# Altium schematic sheet Estimador.SchDoc
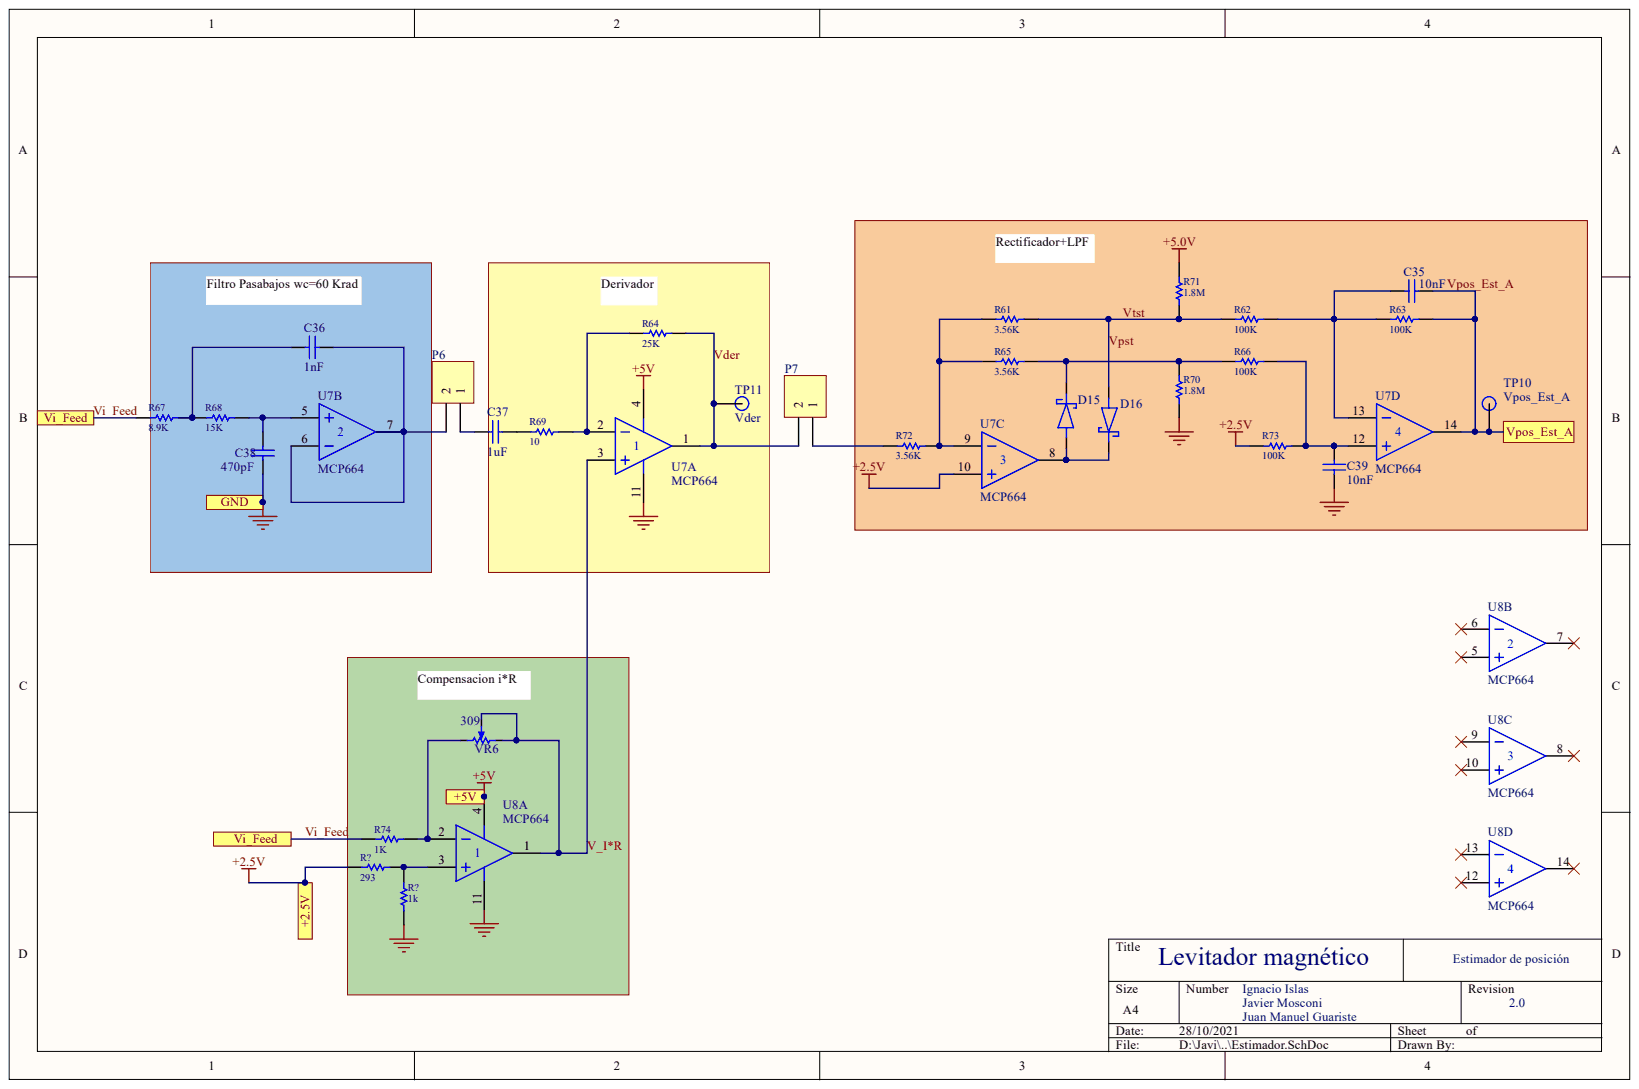
[diagram p0: Estimador.SchDoc]

## Parts
C35  value 10nF  [C1206]  (hidden comment: Capacitor)
C36  value 1nF  [C1206]  (hidden comment: Capacitor)
C37  value 1uF  [C1206]  (hidden comment: Capacitor)
C38  value 470pF  [C1206]  (hidden comment: Capacitor)
C39  value 10nF  [C1206]  (hidden comment: Capacitor)
D15  RSX205LAM30TR  [SODFL4725X105N]  (value not drawn)
D16  RSX205LAM30TR  [SODFL4725X105N]  (value not drawn)
P6  Header 2  [HDR1X2]  (value not drawn)
P7  Header 2  [HDR1X2]  (value not drawn)
R61  3.56K  [R1206]
R62  100K  [R1206]
R63  100K  [R1206]
R64  25K  [R1206]
R65  3.56K  [R1206]
R66  100K  [R1206]
R67  8.9K  [R1206]
R68  15K  [R1206]
R69  10  [R1206]
R70  1.8M  [R1206]
R71  1.8M  [R1206]
R72  3.56K  [R1206]
R73  100K  [R1206]
R74  1K  [R1206]
R?  1k  [R1206]
R?  293  [R1206]
TP10  Vpos_Est_A  [TestPoint Keystone 5000 Silver-Red]
TP11  Vder  [TestPoint Keystone 5000 Silver-Red]
U7  MCP664  [SOIC14]  (parts A,B,C,D)
U8  MCP664  [SOIC14]  (parts A,B,C,D)
VR6  value 309  [3299Y1203LF]  (hidden comment: Trimmer (Thru-Hole))

## Ports
+2.5V, +5V, GND, VI_FEED, VPOS_EST_A

## Nets
+5.0V: R71.2
+2.5V: R73.2 R?.1 U7.10 ; port +2.5V
+5V: U7.4 U8.4 ; port +5V
GND: C38.2 C39.1 R70.1 R?.1 U7.11 U8.11 ; port GND
V_I*R: U7.3 U8.1 VR6.2 VR6.3
VDER: P7.2 R64.2 TP11.1 U7.1
VI_FEED: R67.1 R74.1 ; port VI_FEED
VPOS_EST_A: C35.1 R63.1 TP10.1 U7.14 ; port VPOS_EST_A
VPST: D16.2 R61.2 R62.1 R71.1
NetC35_2: C35.2 R62.2 R63.2 U7.13
NetC36_1: C36.1 P6.2 U7.6 U7.7
NetC36_2: C36.2 R67.2 R68.1
NetC37_1: C37.1 P6.1
NetC37_2: C37.2 R69.1
NetC38_1: C38.1 R68.2 U7.5
NetC39_2: C39.2 R66.2 R73.1 U7.12
NetD15_1: D15.1 R65.2 R66.1 R70.2
NetD15_2: D15.2 D16.1 U7.8
NetP7_1: P7.1 R72.1
NetR61_1: R61.1 R65.1 R72.2 U7.9
NetR64_1: R64.1 R69.2 U7.2
NetR74_2: R74.2 U8.2 VR6.1
NetR?_2: R?.2 U8.3

Not connected: U8 5 6 7 8 9 10 12 13 14

## Library data of the parts
C35-C39 = Capacitor: Manufacturer: Generic Components; Class: Passive; Component Kind: Standard; Description: Capacitor; Published: 08/06/2000; SubClass: Capacitor; Library Name: Levitador_GMI.SchLib; Publisher: Altium Hobart Technology Centre
D15 D16 = RSX205LAM30TR: Schottky Diode; Manufacturer_Name: ROHM Semiconductor; Component Kind: Standard; Datasheet Link: https://fscdn.rohm.com/en/products/databook/datasheet/discrete/diode/schottky_barrier/rsx205lam30tr-e.pdf; Height: 1.05mm; Manufacturer_Part_Number: RSX205LAM30TR; Mouser Part Number: 755-RSX205LAM30TR; Mouser Price/Stock: https://www.mouser.co.uk/ProductDetail/ROHM-Semiconductor/RSX205LAM30TR?qs=gt1LBUVyoHmFfSK22qN0cQ%3D%3D; Library Name: Levitador_GMI.SchLib
P6 P7 = Header 2: Header, 2-Pin; Component Kind: Standard; LatestRevisionDate: 17-Jul-2002; LatestRevisionNote: Re-released for DXP Platform.; Link: https://www.digikey.com/en/products/detail/te-connectivity-amp-connectors/282834-2/1150135; Signal Integrity: Connector; Library Name: Levitador_GMI.SchLib; Publisher: Altium Limited
R61-R74 R? R? = R1206: Resistor Chip; 1/8W;; Manufacturer: Panasonic; Tolerance: 1%; Component Kind: Standard; LatestRevisionDate: 29/7/2021; PackageDescription: SMT Chip Resistor; PackageReference: 1206; Simulation: RESISTOR; Supplier 1: Digi-Key; Library Name: Levitador_GMI.SchLib
  R61 R65 R72: Value: 3.56K
  R62 R63 R66 R73: Value: 100K
  R64: Value: 25K
  R67: Value: 8.9K
  R68: Value: 15K
  R69: Value: 10
  R70 R71: Value: 1.8M
  R74: Value: 1K
  R?: Value: 1k
  R?: Value: 293
TP10 TP11 = Testpoint: Component Kind: Standard; Link: https://www.digikey.com/en/products/detail/keystone-electronics/5000/255326; Library Name: Levitador_GMI.SchLib
U7 U8 = MCP664: Part Number: MCP664-E/ST; Component Kind: Standard; Datasheet: https://ar.mouser.com/datasheet/2/268/20002194E-2448650.pdf; Library Name: Levitador_GMI.SchLib
VR6 = Trimmer: Res Cermet Trimmer 500K Ohm 10% 0.5W 25(Elec)Turn 2.19mm (9.53 X 4.83 X 11.55mm) Pin Thru-Hole Tube; MF: {'manufacturer': u'Bourns'}; MP: 3296W-1-250; Availability: Unavailable; Component Kind: Standard; Package: None; Price: None; Library Name: Levitador_GMI.SchLib
